# Altium schematic sheet LED 按键.SchDoc("LED 按键")
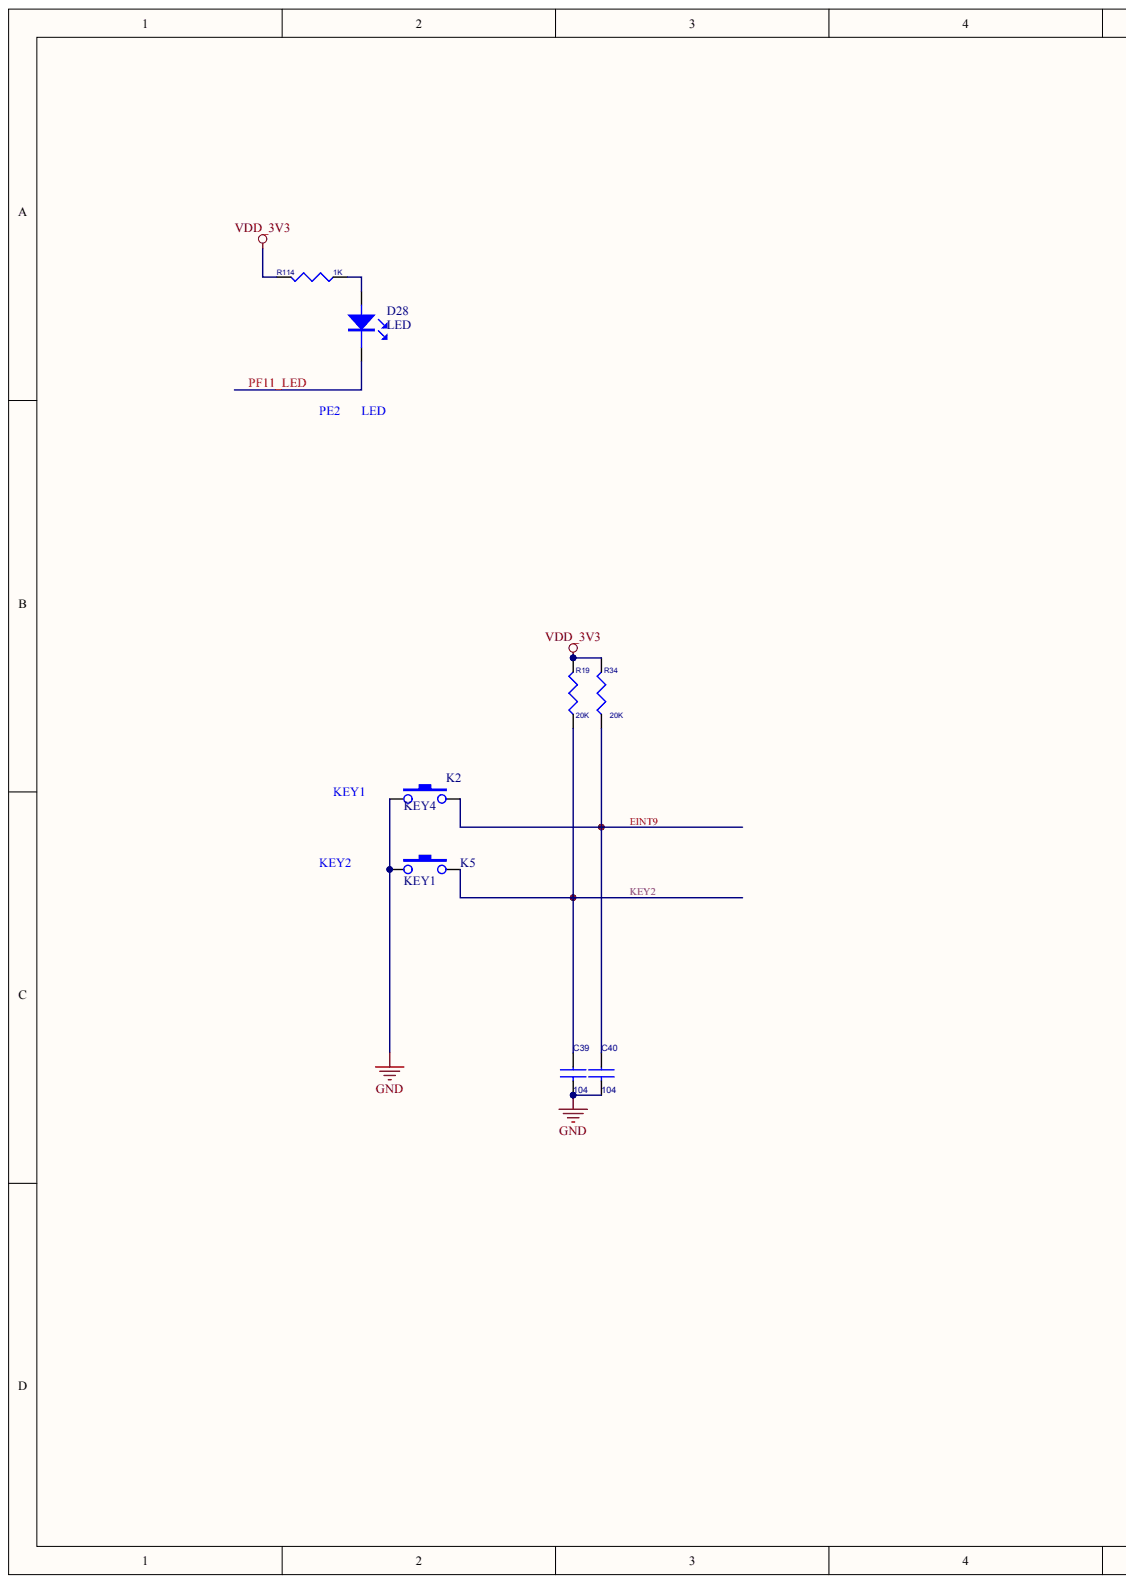
[diagram p0: LED 按键.SchDoc, left]
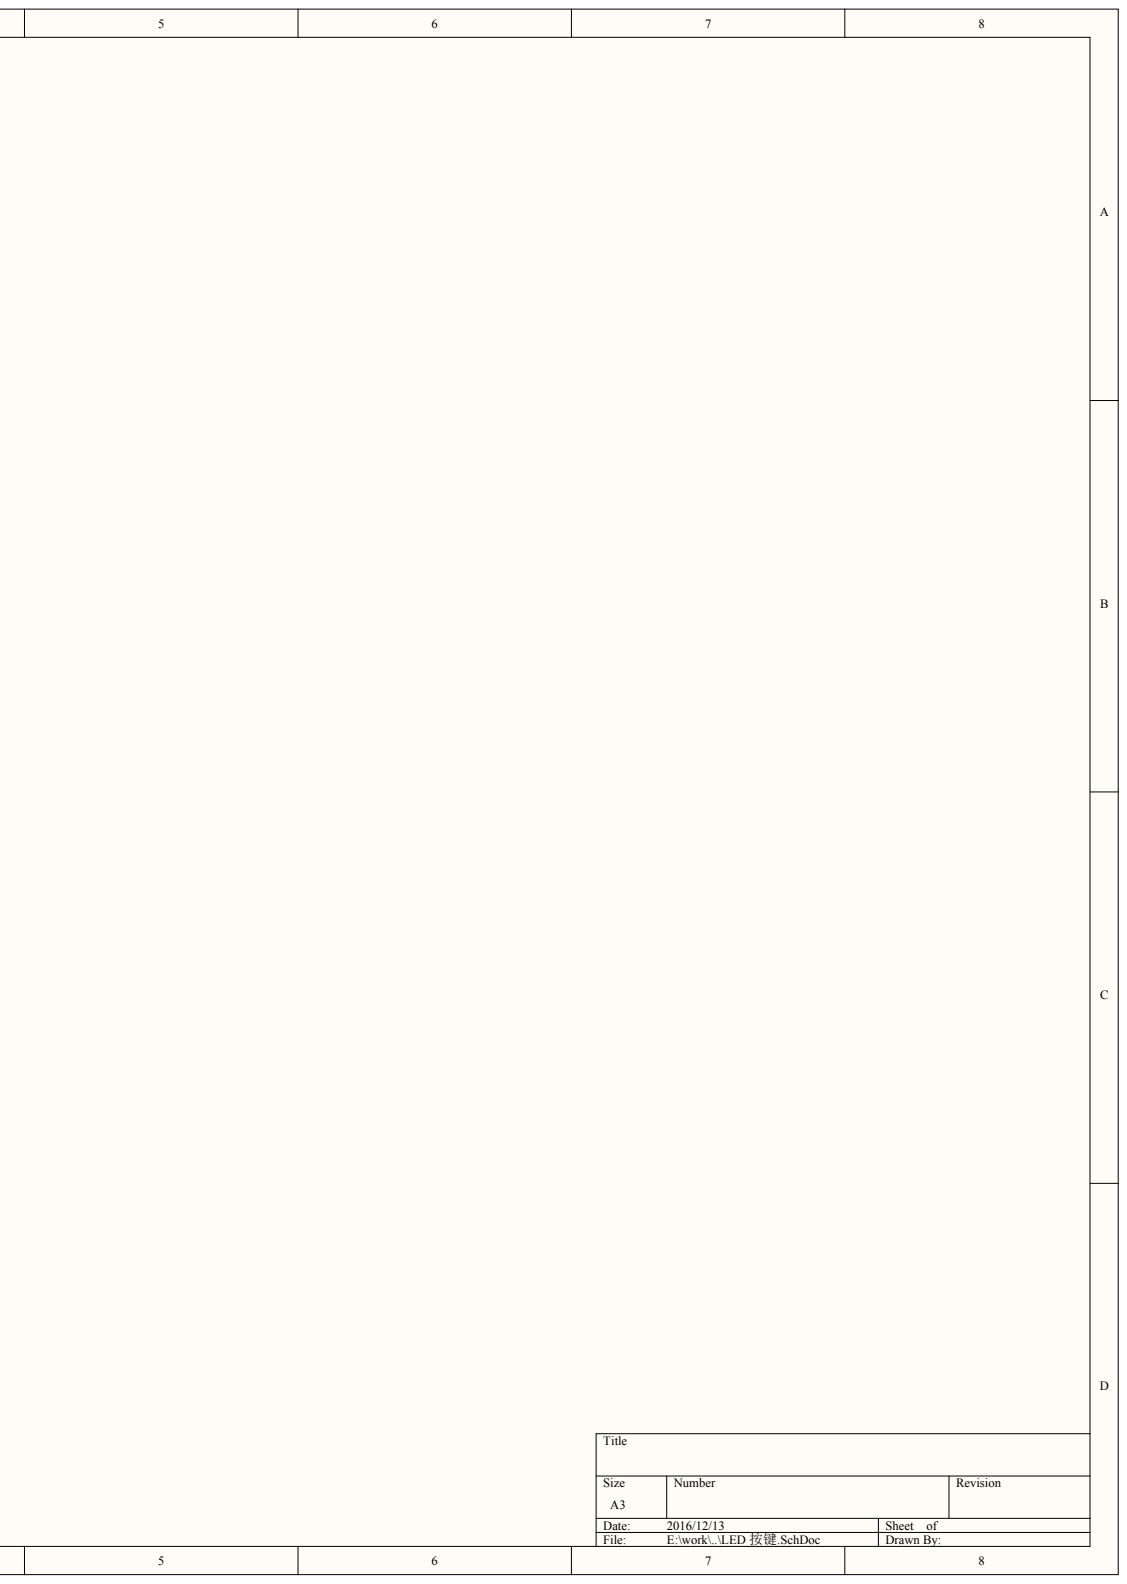
[diagram p1: LED 按键.SchDoc, right]

## Parts
C39  104
C40  104
D28  LED
K2  KEY4
K5  KEY1
R19  20K
R34  20K
R114  1K

## Nets
GND: C39.2 C40.2 K2.1 K5.1
VDD_3V3: R19.2 R34.2 R114.2
EINT9: C40.1 K2.2 R34.1
KEY2: C39.1 K5.2 R19.1
PF11_LED: D28.2
NetD28_1: D28.1 R114.1

## Library data of the parts
C39 C40 = (no symbol name): Right: 20; Bottom: 10
R19 R34 R114 = (no symbol name): 1ST PART FIELD: SMD-R(1608); Right: 20; Bottom: 30
